# Altium schematic sheet Power Management.SchDoc
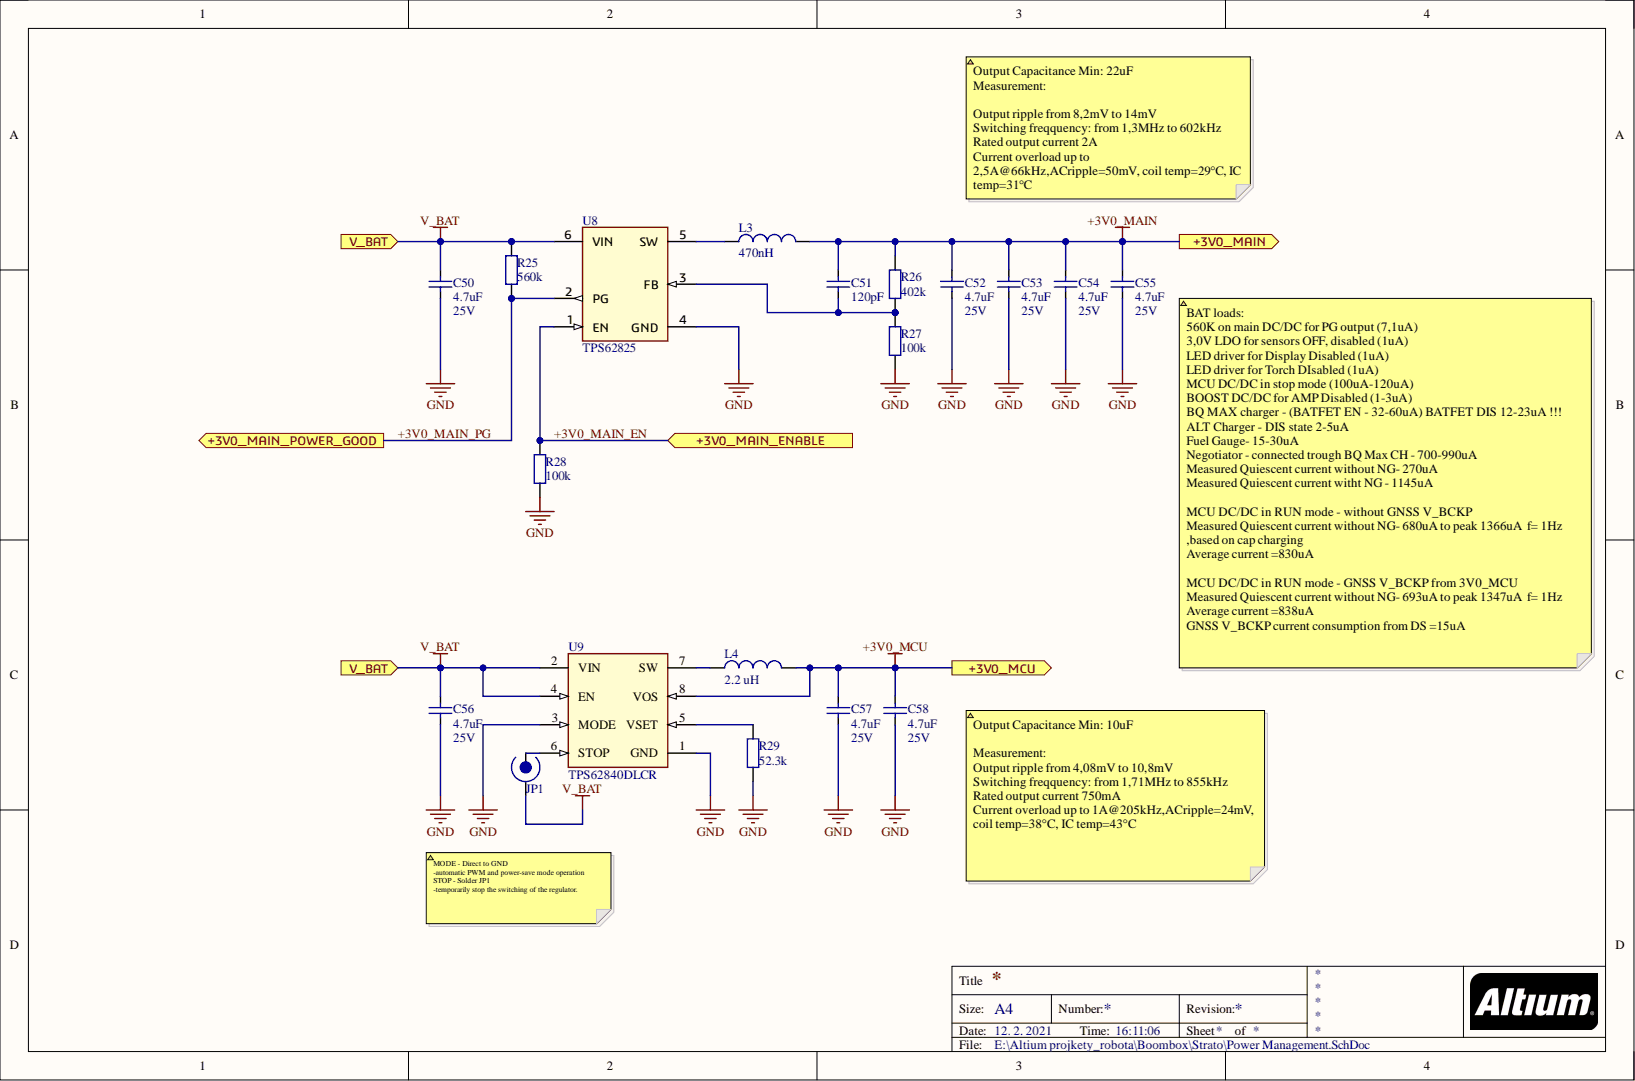
[diagram p0: Power Management.SchDoc]

## Parts
C50  4.7uF  Voltage: 25V  [CAPACITOR_0603_(1608)]
C51  120pF  [CAPACITOR_0603_(1608)]
C52  4.7uF  Voltage: 25V  [CAPACITOR_0603_(1608)]
C53  4.7uF  Voltage: 25V  [CAPACITOR_0603_(1608)]
C54  4.7uF  Voltage: 25V  [CAPACITOR_0603_(1608)]
C55  4.7uF  Voltage: 25V  [CAPACITOR_0603_(1608)]
C56  4.7uF  Voltage: 25V  [CAPACITOR_0603_(1608)]
C57  4.7uF  Voltage: 25V  [CAPACITOR_0603_(1608)]
C58  4.7uF  Voltage: 25V  [CAPACITOR_0603_(1608)]
JP1  Jumper  [JUMPER OFF SMD]  (value not drawn)  (no BOM)
L3  470nH  [Power Inductor_XFL4015 (SMD) COILCRAFT]
L4  2.2 uH  [L_0806]
R25  560k  [RESISTOR_0603_(1608)]
R26  402k  [RESISTOR_0603_(1608)]
R27  100k  [RESISTOR_0603_(1608)]
R28  100k  [RESISTOR_0603_(1608)]
R29  52.3k  [RESISTOR_0603_(1608)]
U8  TPS62825  [6VSON-HR]
U9  TPS62840DLCR  [8VSON-HR]

## Ports
+3V0_MAIN, +3V0_MAIN_ENABLE, +3V0_MAIN_POWER_GOOD, +3V0_MCU, V_BAT

## Nets
GND: C50.2 C52.2 C53.2 C54.2 C55.2 C56.2 C57.2 C58.2 R27.1 R28.1 R29.1 U8.4(GND) U9.1(GND) U9.3(MODE)
+3V0_MAIN: C51.2 C52.1 C53.1 C54.1 C55.1 L3.2 R26.2 ; port +3V0_MAIN
+3V0_MAIN_EN: R28.2 U8.1(EN) ; port +3V0_MAIN_ENABLE
+3V0_MAIN_PG: R25.1 U8.2(PG) ; port +3V0_MAIN_POWER_GOOD
+3V0_MCU: C57.1 C58.1 L4.2 U9.8(VOS) ; port +3V0_MCU
V_BAT: C50.1 C56.1 JP1.1 R25.2 U8.6(VIN) U9.2(VIN) U9.4(EN) ; port V_BAT
NetC51_1: C51.1 R26.1 R27.2 U8.3(FB)
NetJP1_2: JP1.2 U9.6(STOP)
NetL3_1: L3.1 U8.5(SW)
NetL4_1: L4.1 U9.7(SW)
NetR29_2: R29.2 U9.5(VSET)

## Library data of the parts
C50 C52-C58 = CAPACITOR: Manufacturer: Murata; Manufacturer Part Number 1: GRM188R61E475KE11D; Dielectric: X5R; ESR: 0.28R; Material: Ceramic; Tolerance: 10%; Capacitance: 4.7uF; Case Code (Imperial): 0603; Case Code (Metric): 1608; Case/Package: 0603; Component Kind: Standard; ComponentLink1Description: https://datasheet.ciiva.com/46444/1769893-46444982.pdf?src-supplier=Element14; ComponentLink1URL: https://datasheet.ciiva.com/46444/1769893-46444982.pdf?src-supplier=Element14; ComponentLink2Description: https://datasheet.ciiva.com/46444/1769893-46444983.pdf?src-supplier=Element14; ComponentLink2URL: https://datasheet.ciiva.com/46444/1769893-46444983.pdf?src-supplier=Element14; ComponentLink3Description: https://datasheet.ciiva.com/14891/partnumbering-e-01-14891102.pdf?src-supplier=Digi-Key; ComponentLink3URL: https://datasheet.ciiva.com/14891/partnumbering-e-01-14891102.pdf?src-supplier=Digi-Key; ComponentLink4Description: https://datasheet.ciiva.com/14891/partnumbering-e-01-14891103.pdf?src-supplier=Digi-Key; ComponentLink4URL: https://datasheet.ciiva.com/14891/partnumbering-e-01-14891103.pdf?src-supplier=Digi-Key; Depth: 0.8mm; Description: CAPACITOR; Height: 0.8mm; Lead Free: Lead Free; Length: 1.6mm; Max Operating Temperature: 85°C; Min Operating Temperature: -55°C; Mount: Surface Mount; Package Quantity: 4000; Packaging: Cut Tape; Pricing 1: 10=0,35700, 100=100,00000, 500=0,15900, 1000=0,12800, 2000=0,10200 (CHF); REACH SVHC: No SVHC; RoHS: Yes; Stock 1: 95119; Supplier 1: Farnell; Supplier Part Number 1: 2426959; Thickness: 0.031inch; Voltage Rating: 25V; Voltage Rating (DC): 25V; Width: 0.031inch; Library Name: RLCFB.SchLib
C51 = CAPACITOR: ESR: 0.28R; Voltage Rating: 10V; Capacitance: 120pF; Component Kind: Standard; Description: CAPACITOR; Library Name: RLCFB.SchLib
JP1 = Jumper SMD: Description: Jumper; Library Name: Source.SchLib
L3 = INDUCTOR: COILCRAFT   XFL4015-471MEB   Surface Mount Power Inductor, XFL4015 Series, 470 nH,  20%, Shielded, 8360 ohm, 11.2 A; Core Material: Composite; DC Resistance (DCR): 8.36mR; Max DC Current: 11.2A; Saturation Current: 3.5A; Self Resonant Frequency: 89MHz; Test Frequency: 100kHz; Case/Package: SMD/SMT; Category: Fixed Inductors; Component Kind: Standard; ComponentLink1Description: https://datasheet.ciiva.com/22679/1682009-22679271.pdf?src-supplier=Element14; ComponentLink1URL: https://datasheet.ciiva.com/22679/1682009-22679271.pdf?src-supplier=Element14; ComponentLink2Description: https://datasheet.ciiva.com/22701/1682009-22701743.pdf?src-supplier=Element14; ComponentLink2URL: https://datasheet.ciiva.com/22701/1682009-22701743.pdf?src-supplier=Element14; Height: 1.6mm; Inductance: 470nH; Inductance Tolerance: 20%; Lead Free: Contains Lead; Length: 4.3mm; Manufacturer: Coilcraft; Manufacturer Part Number: XFL4015-471MEB; Max Operating Temperature: 125°C; Min Operating Temperature: -40°C; Mount: Surface Mount; Package Quantity: 40; Packaging: Tape and Reel; Pricing 1: 1=1,68000, 10=1,50000, 50=1,35000, 100=1,20000, 200=1,14000, 500=0,94400 (USD); REACH SVHC: No SVHC; RMS Current (Irms): 11.2A; RoHS: Yes; Shielding: Shielded; Stock 1: 4093; Supplier 1: Mouser; Supplier Part Number 1: 994-XFL4015-471MEB; Termination: SMD/SMT; Tolerance: 20%; Width: 4.3mm; Library Name: RLCFB.SchLib
L4 = INDUCTOR: Inductor Power Shielded Wirewound 2.2uH 20% 1MHz Metal 1.8A 0.116Ohm DCR 0806 T/R; Manufacturer: Murata; Manufacturer Part Number: DFE201612E-2R2M=P2; Category: Fixed Inductors; Component Kind: Standard; ComponentLink1Description: http://www.farnell.com/datasheets/2339714.pdf?src-supplier=Farnell; ComponentLink1URL: http://www.farnell.com/datasheets/2339714.pdf?src-supplier=Farnell; ComponentLink2Description: https://search.murata.co.jp/Ceramy/image/img/P02/J(E)TE243A-0006.pdf?src-supplier=Digi-Key; ComponentLink2URL: https://search.murata.co.jp/Ceramy/image/img/P02/J(E)TE243A-0006.pdf?src-supplier=Digi-Key; ComponentLink3Description: http://www.farnell.com/datasheets/2339714.pdf?src-supplier=Newark; ComponentLink3URL: http://www.farnell.com/datasheets/2339714.pdf?src-supplier=Newark; Inductance: 2.2 uH; Pricing 1: 1=0,49000, 10=0,43300, 100=0,30900, 500=0,22600, 1000=0,18300, 3000=0,15900 (USD); RoHS: No; Stock 1: 24477; Supplier 1: Mouser; Supplier Part Number 1: 81-DFE201612E-2R2MP2; Library Name: RLCFB.SchLib
R25-R29 = RESISTOR: Power Rating: 500mW; Component Kind: Standard; Description: RESISTOR; Library Name: RLCFB.SchLib
  R25: Resistance: 560k
  R26: Resistance: 402k
  R27 R28: Resistance: 100k
  R29: Resistance: 52.3k
U8 = DC/DC_TPS62825: 2.4V-5.5V input, 2A step-down converter with 1% Accuracy in 1.5mm x 1.5mm QFN 6-VSON-HR -40 to 125; Manufacturer: Texas Instruments; Manufacturer Part Number: TPS62825DMQR; Max Input Voltage: 5.5V; Max Output Voltage: 4V; Min Input Voltage: 2.4V; Min Output Voltage: 600mV; Number of Outputs: 1; Output Configuration: Positive; Output Type: Adjustable; Switching Frequency: 2.2MHz; Synchronous Rectifier: Yes; Category: Voltage Regulators - Switching; Component Kind: Standard; ComponentLink1Description: http://www.ti.com/lit/ds/symlink/tps62825.pdf?src-supplier=Digi-Key; ComponentLink1URL: http://www.ti.com/lit/ds/symlink/tps62825.pdf?src-supplier=Digi-Key; Library Name: BB1_SCH_Library.SchLib; Max Operating Temperature: 125°C; Min Operating Temperature: -40°C; Mount: Surface Mount; Number of Pins: 6; Output Current: 2A; Packaging: Tape and Reel; Pricing 1: 1=1,36000, 10=1,15000, 100=0,88200, 250=0,78000, 500=0,73800, 1000=0,57400 (USD); RoHS: No; Stock 1: 9123; Supplier 1: Mouser; Supplier Part Number 1: 595-TPS62825DMQR
U9 = DC/DC_TPS62840DLC: 60-nA IQ, 1.8-V to 6.5-VIN, high-efficiency 750-mA step-down converter 8-VSON-HR -40 to 125; Manufacturer: Texas Instruments; Category: Voltage Regulators - Switching; Component Kind: Standard; Library Name: BB1_SCH_Library.SchLib; Manufacturer Part Number: TPS62840DLCR; Pricing 1: 1=1,83000, 10=1,55000, 100=1,24000, 250=1,09000, 500=1,06000, 1000=0,83700 (USD); RoHS: No; Stock 1: 21842; Supplier 1: Mouser; Supplier Part Number 1: 595-TPS62840DLCR
